# Altium schematic sheet Power.SchDoc(Power)
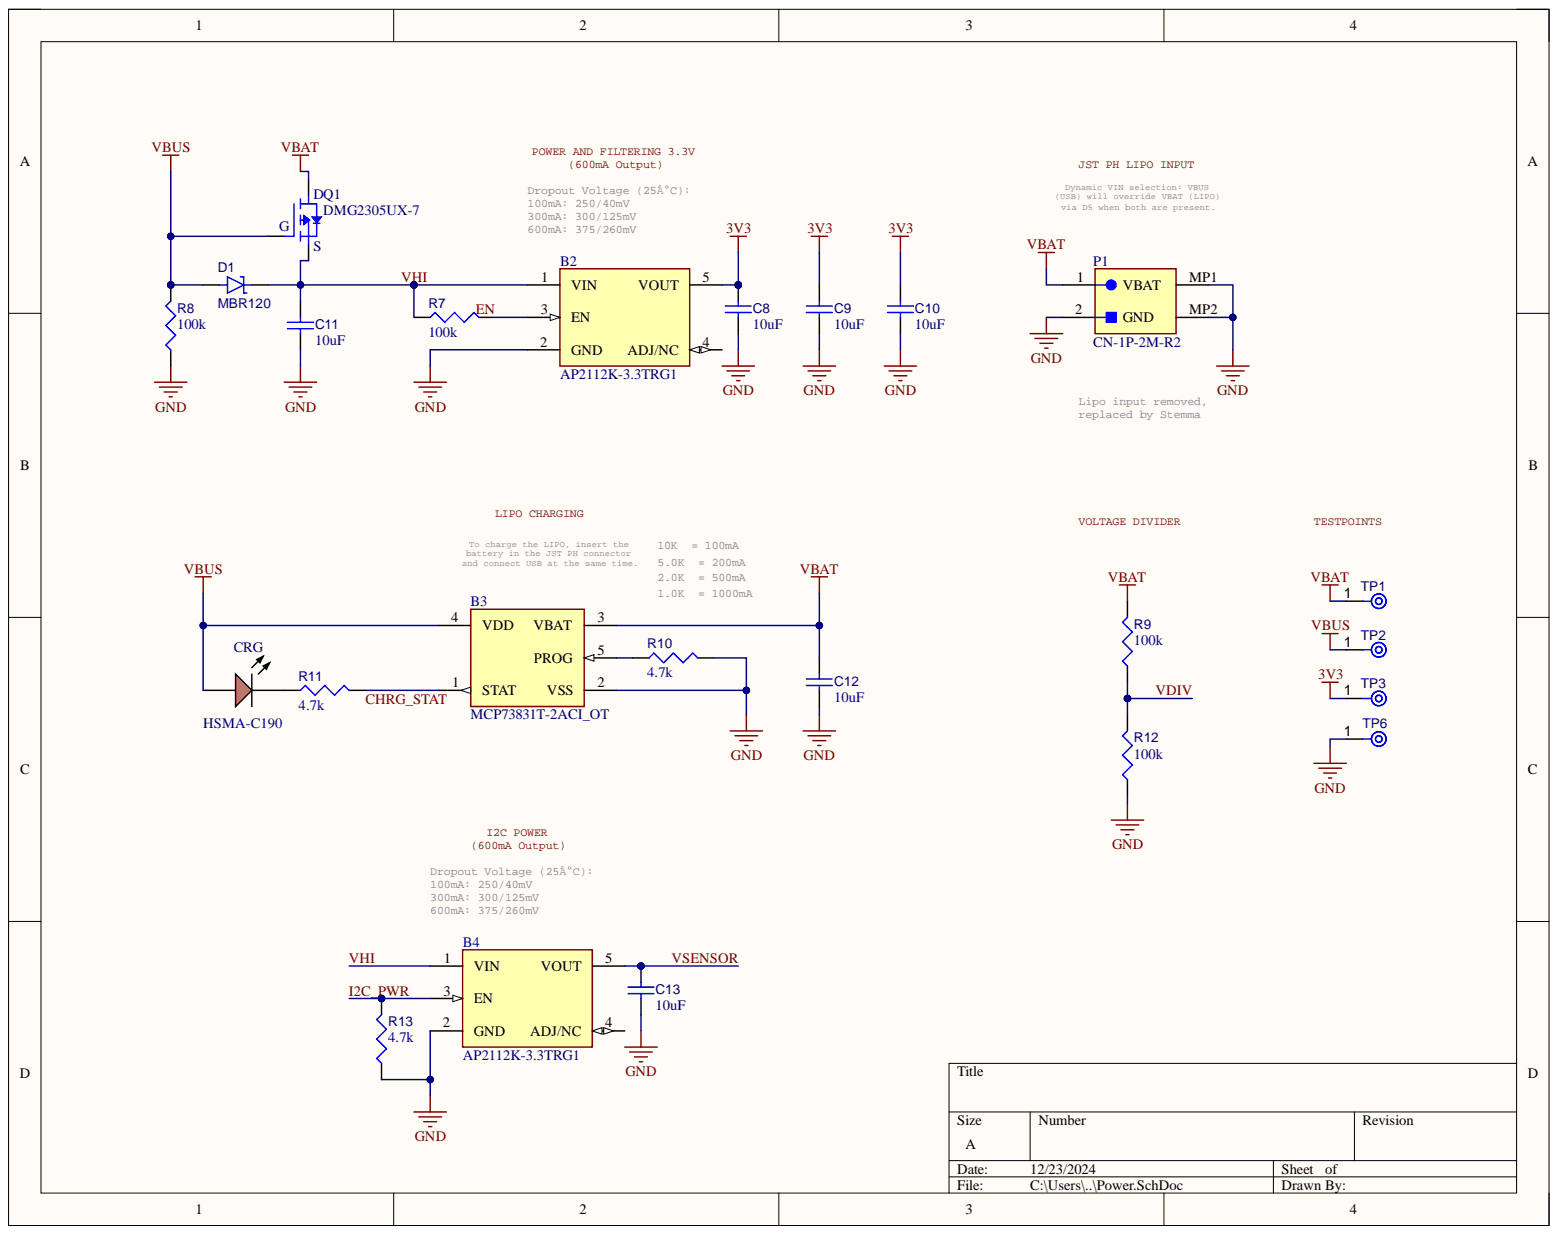
[diagram p0: Power.SchDoc]

## Parts
B2  AP2112K-3.3TRG1  [SOT95P270X145-5N]
B3  MCP73831T-2ACI_OT  [SOT95P270X145-5N]
B4  AP2112K-3.3TRG1  [SOT95P270X145-5N]
C8  value 10uF  [CAPC1608X87X35NL15T15]  (hidden comment: Capacitor 10 uF +/- 0.25 uF 50 V 0603)
C9  value 10uF  [CAPC1608X87X35NL15T15]  (hidden comment: Capacitor 10 uF +/- 0.25 uF 50 V 0603)
C10  value 10uF  [CAPC1608X87X35NL15T15]  (hidden comment: Capacitor 10 uF +/- 0.25 uF 50 V 0603)
C11  value 10uF  [CAPC1608X87X35NL15T15]  (hidden comment: Capacitor 10 uF +/- 0.25 uF 50 V 0603)
C12  value 10uF  [CAPC1608X87X35NL15T15]  (hidden comment: Capacitor 10 uF +/- 0.25 uF 50 V 0603)
C13  value 10uF  [CAPC1608X87X35NL15T15]  (hidden comment: Capacitor 10 uF +/- 0.25 uF 50 V 0603)
CRG  HSMA-C190  [AVAG-HSMX-C190_V]
D1  MBR120  [ONSC-SOD-123FL-2-498-01_V]
P1  CN-1P-2M-R2  [JST- S2B-PH-SM4-TB(LF)(SN)-2_V]
Q1  DMG2305UX-7  [FP-SOT23-MFG]
R7  value 100k  [RESC1608X55X25ML10T15]  (hidden comment: Resistor 100k +/-1% 0603 100 mW)
R8  value 100k  [RESC1608X55X25ML10T15]  (hidden comment: Resistor 100k +/-1% 0603 100 mW)
R9  value 100k  [RESC1608X55X25ML10T15]  (hidden comment: Resistor 100k +/-1% 0603 100 mW)
R10  value 4.7k  [RESC1608X55X25ML10T15]  (hidden comment: Resistor 4.7k +/-1% 0603 100 mW)
R11  value 4.7k  [RESC1608X55X25ML10T15]  (hidden comment: Resistor 1k +/-1% 0603 100 mW)
R12  value 100k  [RESC1608X55X25ML10T15]  (hidden comment: Resistor 100k +/-1% 0603 100 mW)
R13  value 4.7k  [RESC1608X55X25ML10T15]  (hidden comment: Resistor 4.7k +/-1% 0603 100 mW)
TP1  TPAD6R  [TP06R]  (value not drawn)  (no BOM)
TP2  TPAD6R  [TP06R]  (value not drawn)  (no BOM)
TP3  TPAD6R  [TP06R]  (value not drawn)  (no BOM)
TP6  TPAD6R  [TP06R]  (value not drawn)  (no BOM)

## Nets
3V3: B2.5(VOUT) C8.2 C9.2 C10.2 TP3.1
GND: B2.2(GND) B3.2(VSS) B4.2(GND) C8.1 C9.1 C10.1 C11.1 C12.1 C13.1 P1.2 P1.MP1(VBAT) P1.MP2(GND) R8.1 R10.2 R12.1 R13.1 TP6.1
VBAT: B3.3(VBAT) C12.2 P1.1 Q1.3 R9.2 TP1.1
VBUS: B3.4(VDD) CRG.1 D1.2 Q1.1 R8.2 TP2.1
CHRG_STAT: B3.1(STAT) R11.2
EN: B2.3(EN) R7.2
I2C_PWR: B4.3(EN) R13.2
VDIV: R9.1 R12.2
VHI: B2.1(VIN) B4.1(VIN) C11.2 D1.1 Q1.2 R7.1
VSENSOR: B4.5(VOUT) C13.2
NetB3_5: B3.5(PROG) R10.1
NetCRG_2: CRG.2 R11.1

Not connected: B2 4(ADJ/NC); B4 4(ADJ/NC)

## Library data of the parts
B2 B4 = CMP-007-000001-1: 600mA 3.3V LDO Regulator Enable SOT23-5 DiodesZetex AP2112K-3.3TRG1, LDO Voltage Regulator, 3.3 V +/-1.5%, 2.5  6 Vin, 5-Pin SOT-23; Manufacturer_Name: Diodes Inc.; Geometry.Height: 1.3mm; Component Kind: Standard; Design Item ID: AP2112K-3.3TRG1; Manufacturer_Part_Number: AP2112K-3.3TRG1; Mouser Part Number: 621-AP2112K-3.3TRG1; Mouser Price/Stock: https://www.mouser.co.uk/ProductDetail/Diodes-Incorporated/AP2112K-3.3TRG1?qs=x6A8l6qLYDDPYHosCdzh%2FA%3D%3D; Source: AP2112K-3.3TRG1.SchLib; Library Name: Prototypical Design
B3 = CMP-009-000000-1: Li-Ion Charge Controller 4.2V SOT23-5; Manufacturer_Name: Microchip; Manufacturer_Part_Number: MCP73831T-2ACI/OT; Geometry.Height: 1.45mm; Component Kind: Standard; Datasheet Link: http://ww1.microchip.com/downloads/en/DeviceDoc/20001984g.pdf; Design Item ID: MCP73831T-2ACI_OT; Mouser Part Number: 579-MCP73831T-2ACIOT; Mouser Price/Stock: https://www.mouser.co.uk/ProductDetail/Microchip-Technology-Atmel/MCP73831T-2ACI-OT?qs=yUQqVecv4qvbBQBGbHx0Mw%3D%3D; Source: MCP73831T-2ACI_OT.SchLib; Library Name: Prototypical Design
C8-C13 = CMP-001-00012-6: Chip Capacitor, 10 uF, +/- 0.25 uF, 50 V, 0603 (1608 Metric); Capacitor Type: Ceramic; Tolerance: 0.25%; Voltage Rating: 50V; Case/Package: 0603; Component Kind: Standard; Max Operating Temperature: 125°C; Min Operating Temperature: -55°C; Mounting Technology: SM; Pins: 2; RoHS Compliant: True; Library Name: Prototypical Design
CRG = CMP-007-00011-2: LED Uni-Color Amber, 60 mW, 20 mA, -40 to 85 degC, 2-Pin SMD, RoHS, Tape and Reel; Manufacturer: Avago; Forward Current: 20mA; Forward Voltage: 1.9V; Illumination Colour: Orange; Lens Style: Diffused; Luminous Intensity: 90mcd; Power: 60mW; Viewing Angle: 170degrees; Case/Package: 0603; Colour: Orange; Component Kind: Standard; Datasheet URL: http://docs.avagotech.com/docs/AV02-0112EN; Datasheet Version: Sep-10; Manufacturer URL: http://www.avagotech.com/; Max Operating Temperature: 85°C; Min Operating Temperature: -40°C; Mounting Technology: SM; Nominal Vf: 1.9V; Package Description: 2-Pin Surface Mount Device, Body 1.6 x 0.8 mm, Height 0.9 mm; Package Quantity: 4000; Package Reference: HSMX-C190; Packaging: TapeandReel; Pins: 2; RoHS Compliant: True; Library Name: Prototypical Design
D1 = CMP-004-00031-1: Diode; Component Kind: Standard; Design Item ID: MBR120; Source: MBR120.SchLib; Library Name: Prototypical Design
P1 = CMP-005-000001-1: Component Kind: Standard; Design Item ID: CN-1P-2M-R2; Source: CN-1P-2M-R2.SchLib; Library Name: Prototypical Design
Q1 = CMP001-1: Power Field-Effect Transistor, 4.2A I(D), 20V, 0.052ohm, 1-Element, P-Channel, Silicon, Metal-oxide Semiconductor FET; Component Kind: Standard; Max Operating Temperature: 150°C; Pins: 3; RoHS Compliant: Yes; Library Name: Prototypical Design
R7-R9 R12 = CMP-009-00023-5: Chip Resistor, 100 KOhm, +/- 1%, 0.1 W, -55 to 155 degC, 0603 (1608 Metric); Power: 100mW; Voltage Rating: 50V; Case/Package: 0603; Component Kind: Standard; Max Operating Temperature: 155°C; Min Operating Temperature: -55°C; Mounting Technology: SM; Pins: 2; RoHS Compliant: True; Tolerance: 1%; Library Name: Prototypical Design
R10 R13 = CMP-009-00023-5: Chip Resistor, 4.7 KOhm, +/- 1%, 0.1 W, -55 to 155 degC, 0603 (1608 Metric); Power: 100mW; Voltage Rating: 50V; Case/Package: 0603; Component Kind: Standard; Max Operating Temperature: 155°C; Min Operating Temperature: -55°C; Mounting Technology: SM; Pins: 2; RoHS Compliant: True; Tolerance: 1%; Library Name: Prototypical Design
R11 = CMP-009-00023-5: Chip Resistor, 1 KOhm, +/- 1%, 0.1 W, -55 to 155 degC, 0603 (1608 Metric); Power: 100mW; Voltage Rating: 50V; Case/Package: 0603; Component Kind: Standard; Max Operating Temperature: 155°C; Min Operating Temperature: -55°C; Mounting Technology: SM; Pins: 2; RoHS Compliant: True; Tolerance: 1%; Library Name: Prototypical Design
TP1-TP3 TP6 = CMP-00028-00002-2: 0.6mm round; Pins: 1; Library Name: McIntyre Industries
